# Altium schematic sheet Sheet1.SchDoc(Sheet1)
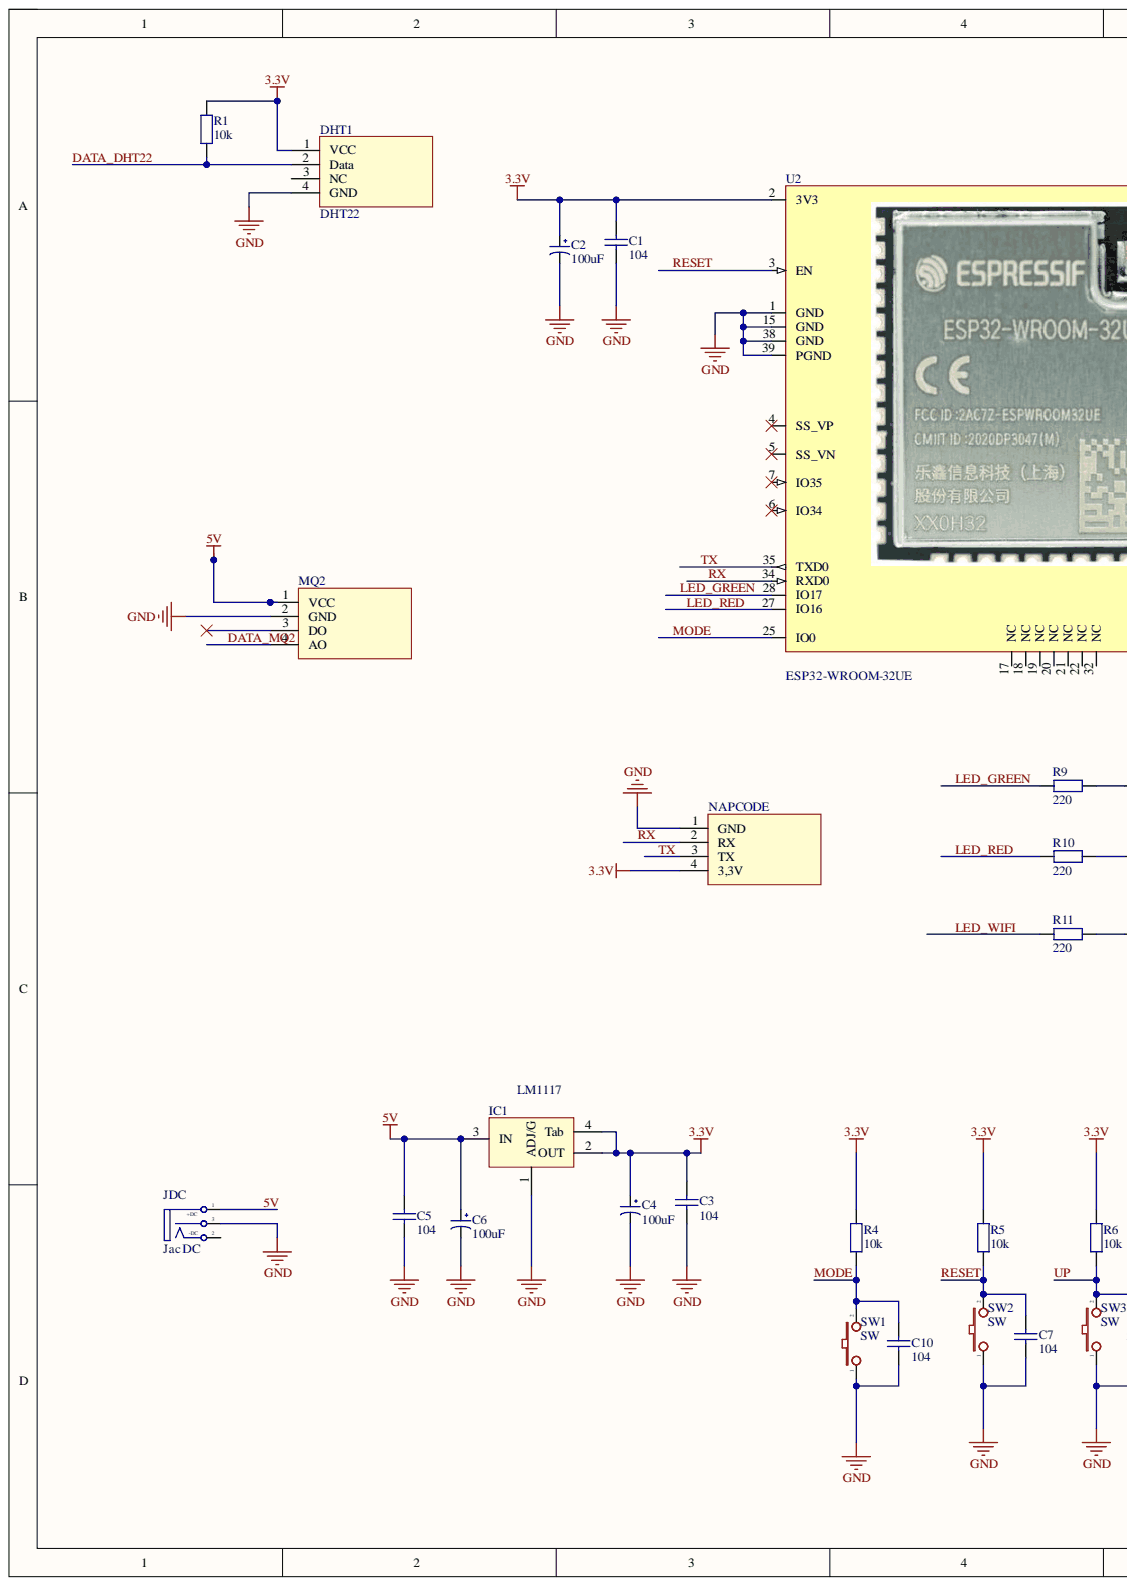
[diagram p0: Sheet1.SchDoc, left]
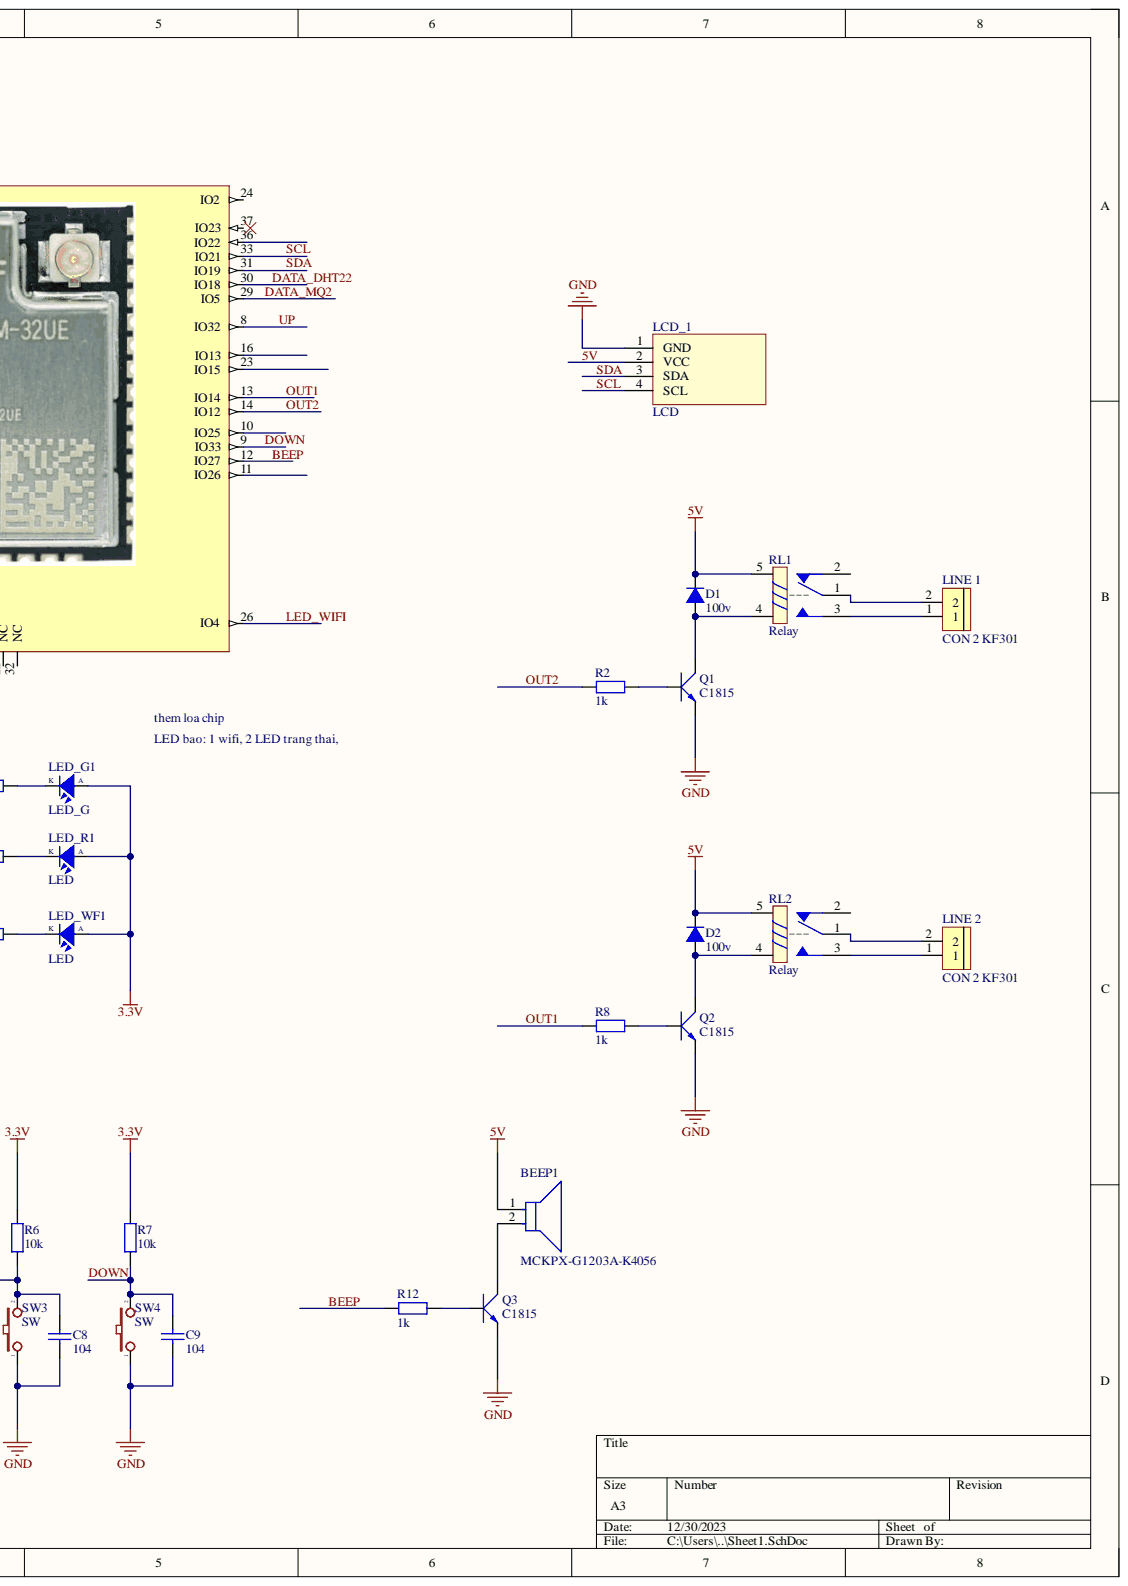
[diagram p1: Sheet1.SchDoc, right]

## Parts
BEEP1  MCKPX-G1203A-K4056  [FP-MCKPX-G1203A-K4056-MFG]
C1  104  [tu gom]
C2  100uF  [tu hoa 5mm]
C3  104  [tu gom]
C4  100uF  [tu hoa 5mm]
C5  104  [tu gom]
C6  100uF  [tu hoa 5mm]
C7  104  [tu gom]
C8  104  [tu gom]
C9  104  [tu gom]
C10  104  [tu gom]
D1  100v  [DIODE 1A]
D2  100v  [DIODE 1A]
DHT1  DHT22  [jp4]
IC1  LM1117  [SOT223-4N]
JDC  Jac DC  [DC-IN]
LCD_1  LCD  [jp4]
LED_G1  LED_G  [LED-0805]
LED_R1  LED  [LED-0805]
LED_WF1  LED  [LED-0805]
LINE_1  CON 2 KF301  [Con 2 KF301 5.08]
LINE_2  CON 2 KF301  [Con 2 KF301 5.08]
MQ2  LCD  [jp4]  (value not drawn)
NAPCODE  LCD  [jp4]  (value not drawn)
Q1  C1815  [SOT23B]
Q2  C1815  [SOT23B]
Q3  C1815  [SOT23B]
R1  10k  [tro smd 0805]
R2  1k  [tro smd 0805]
R4  10k  [tro smd 0805]
R5  10k  [tro smd 0805]
R6  10k  [tro smd 0805]
R7  10k  [tro smd 0805]
R8  1k  [tro smd 0805]
R9  220  [tro smd 0805]
R10  220  [tro smd 0805]
R11  220  [tro smd 0805]
R12  1k  [tro smd 0805]
RL1  Relay  [RL_SPDT_10A]
RL2  Relay  [RL_SPDT_10A]
SW1  SW  [SW4_SMD]
SW2  SW  [SW4_SMD]
SW3  SW  [SW4_SMD]
SW4  SW  [SW4_SMD]
U2  ESP32-WROOM-32UE  [ESP32-WROOM-32UE]

## Nets
3.3V: C1.1 C2.1 C3.1 C4.1 DHT1.1(VCC) IC1.2(OUT) IC1.4(Tab) LED_G1.1 LED_R1.1 LED_WF1.1 NAPCODE.4(3,3V) R1.1 R4.1 R5.1 R6.1 R7.1 U2.2(3V3)
GND: C1.2 C2.2 C3.2 C4.2 C5.2 C6.2 C7.2 C8.2 C9.2 C10.2 DHT1.4(GND) IC1.1(ADJ/G) JDC.3 LCD_1.1(GND) MQ2.2(GND) NAPCODE.1(GND) Q1.1 Q2.1 Q3.1 SW1.1 SW2.1 SW3.1 SW4.1 U2.1(GND) U2.15(GND) U2.38(GND) U2.39(PGND)
5V: BEEP1.1 C5.1 C6.1 D1.2 D2.2 IC1.3(IN) JDC.1 LCD_1.2(VCC) MQ2.1(VCC) RL1.5 RL2.5
BEEP: R12.2 U2.12(IO27)
DATA_DHT22: DHT1.2(Data) R1.2 U2.30(IO18)
DATA_MQ2: MQ2.4(AO) U2.29(IO5)
DOWN: C9.1 R7.2 SW4.2 U2.9(IO33)
LED_GREEN: R9.1 U2.28(IO17)
LED_RED: R10.1 U2.27(IO16)
LED_WIFI: R11.1 U2.26(IO4)
MODE: C10.1 R4.2 SW1.2 U2.25(IO0)
OUT1: R8.2 U2.13(IO14)
OUT2: R2.2 U2.14(IO12)
RESET: C7.1 R5.2 SW2.2 U2.3(EN)
RX: NAPCODE.2(RX) U2.34(RXD0)
SCL: LCD_1.4(SCL) U2.33(IO21)
SDA: LCD_1.3(SDA) U2.31(IO19)
TX: NAPCODE.3(TX) U2.35(TXD0)
UP: C8.1 R6.2 SW3.2 U2.8(IO32)
NetBEEP1_2: BEEP1.2 Q3.2
NetD1_1: D1.1 Q1.2 RL1.4
NetD2_1: D2.1 Q2.2 RL2.4
NetLED_G1_2: LED_G1.2 R9.2
NetLED_R1_2: LED_R1.2 R10.2
NetLED_WF1_2: LED_WF1.2 R11.2
NetLINE 1_1: LINE_1.1 RL1.3
NetLINE 1_2: LINE_1.2 RL1.1
NetLINE 2_1: LINE_2.1 RL2.3
NetLINE 2_2: LINE_2.2 RL2.1
NetQ1_3: Q1.3 R2.1
NetQ2_3: Q2.3 R8.1
NetQ3_3: Q3.3 R12.1

Not connected: DHT1 3(NC); JDC 2; MQ2 3(DO); RL1 2; RL2 2; U2 4(SS_VP) 5(SS_VN) 6(IO34) 7(IO35) 10(IO25) 11(IO26) 16(IO13) 17(NC) 18(NC) 19(NC) 20(NC) 21(NC) 22(NC) 23(IO15) 24(IO2) 32(NC) 36(IO22) 37(IO23)

## Library data of the parts
BEEP1 = CMP-12433-000001-1: MAGNETIC BUZZER AND TRANSDUCER - More Details; Manufacturer: Multicomp Pro; Current: 30mA; Frequency: 2300Hz; Max Supply Voltage: 5V; Min Supply Voltage: 2V; Operating Supply Voltage: 3V; Standoff Height: 0.5mm; Automotive: No; Category: Speak; Component Kind: Standard; ComponentLink2Description: Datasheet; ComponentLink2URL: http://www.farnell.com/datasheets/1498149.pdf?_ga=2.17855225.1157923166.1572356775-536200964.1569240579; Device Class L1: Electromechanical; Device Class L2: Audio Products; Device Class L3: Buzzers; Height: 9.5mm; Lead Free: Yes; Library Name: Altium Content Vault; Manufacturer Part Number: MCKPX-G1203A-K4056; Octopart: https://octopart.com/mckpx-g1203a-k4056-multicomp-12157154; Package: 0; RoHS: TRUE; Temperature: -20°C to +70°C; Temperature Range High: +70°C; Temperature Range Low: -20°C
C1 C3 C5 C7-C10 = Tu gom 104 50V: Cắm; Don_Vi: Con; Ma_Hang: MH004761; So_Chan: 2; Ten_Linh_Kien: Tụ gốm 104 100nF 50V; Chung_Loai: Cắm; Component Kind: Standard; Library Name: Tu dien.SchLib
C2 C4 C6 = Tu Hoa 100uF 16V 5x11: Cắm; Don_Vi: Con; Ma_Hang: MH008417; So_Chan: 2; Ten_Linh_Kien: Tụ Hóa 100uF 16V 5x11MM; Chung_Loai: Cắm; Component Kind: Standard; Library Name: Tu dien.SchLib
D1 D2 = Diode 1N4007: Cắm; Don_Vi: Con; Ma_Hang: MH001063; Ten_Linh_Kien: Diode 1N4007 1A (DIP); Chung_Loai: Cắm; Component Kind: Standard; Library Name: Diode.SchLib
DHT1 LCD_1 MQ2 NAPCODE = DHT1x: Humidity and Temperature Sensor; Component Kind: Standard; Library Name: PART (1).IntLib
IC1 = LM1117: 3v3; Value: LM317; Component Kind: Standard; Library Name: PART (1).IntLib
JDC = DCIN: Jac DC input; Component Kind: Standard; Library Name: PART (1).IntLib; Value: Jac DC
LED_G1 LED_R1 LED_WF1 = LED: LED Single colour Green and Red; Value: LED 3 ly Single Colour; Component Kind: Standard; Library Name: PART (1).IntLib
LINE_1 LINE_2 = CON 2 KF301: Cắm; Ma_Hang: MH000987; Ten_Linh_Kien: CON 2 KF301-2P 5.08MM Xanh Dương; Chung_Loai: Cắm; Component Kind: Standard; Don_Vi: Con; Library Name: Connector.SchLib
Q1-Q3 = C1815: NPN 600mW, ECB; Component Kind: Standard; Library Name: PART (1).IntLib; Value: C1815
R1 R2 R4-R8 R12 = Tro 0805 5% 0R: Dán; Don_Vi: Con; Ma_Hang: MH005230; So_Chan: 2; Ten_Linh_Kien: Trở 0805 5% 0R; Chung_Loai: Dán; Component Kind: Standard; Library Name: Dien Tro.SchLib
R9-R11 = Tro 0805 1% 1R: Dán; Don_Vi: Con; Ma_Hang: MH004177; So_Chan: 2; Ten_Linh_Kien: Trở 0805 1% 1R; Chung_Loai: Dán; Component Kind: Standard; Library Name: Dien Tro.SchLib
RL1 RL2 = Relay 1: Relay SPDT 5 Pin; Value: Relay SPDT, 10A, 12V; Component Kind: Standard; Library Name: PART (1).IntLib
SW1-SW4 = SW2: Switch button 2 pin; Value: SW chip 2 pin; Component Kind: Standard; Library Name: PART (1).IntLib
U2 = ESP32-WROOM-32UE: Rx Txrx Module Wifi U.fl SMD; Manufacturer 1: Espressif Systems; Manufacturer Part Number 1: ESP32-WROOM-32UE(M113EH3200UH3Q0); Category: RF Receivers, Transceivers; Component Kind: Standard; Description 1: Rx Txrx Module Wifi U.fl SMD; Library Name: PART (1).IntLib; Pricing 1: 650=3,00000 (USD); RoHS: No; Stock: 10282; Supplier 1: Digi-Key; Supplier Part Number 1: 1965-ESP32-WROOM-32UE-N4TR-ND
